# Altium schematic sheet 5.SchDoc(5)
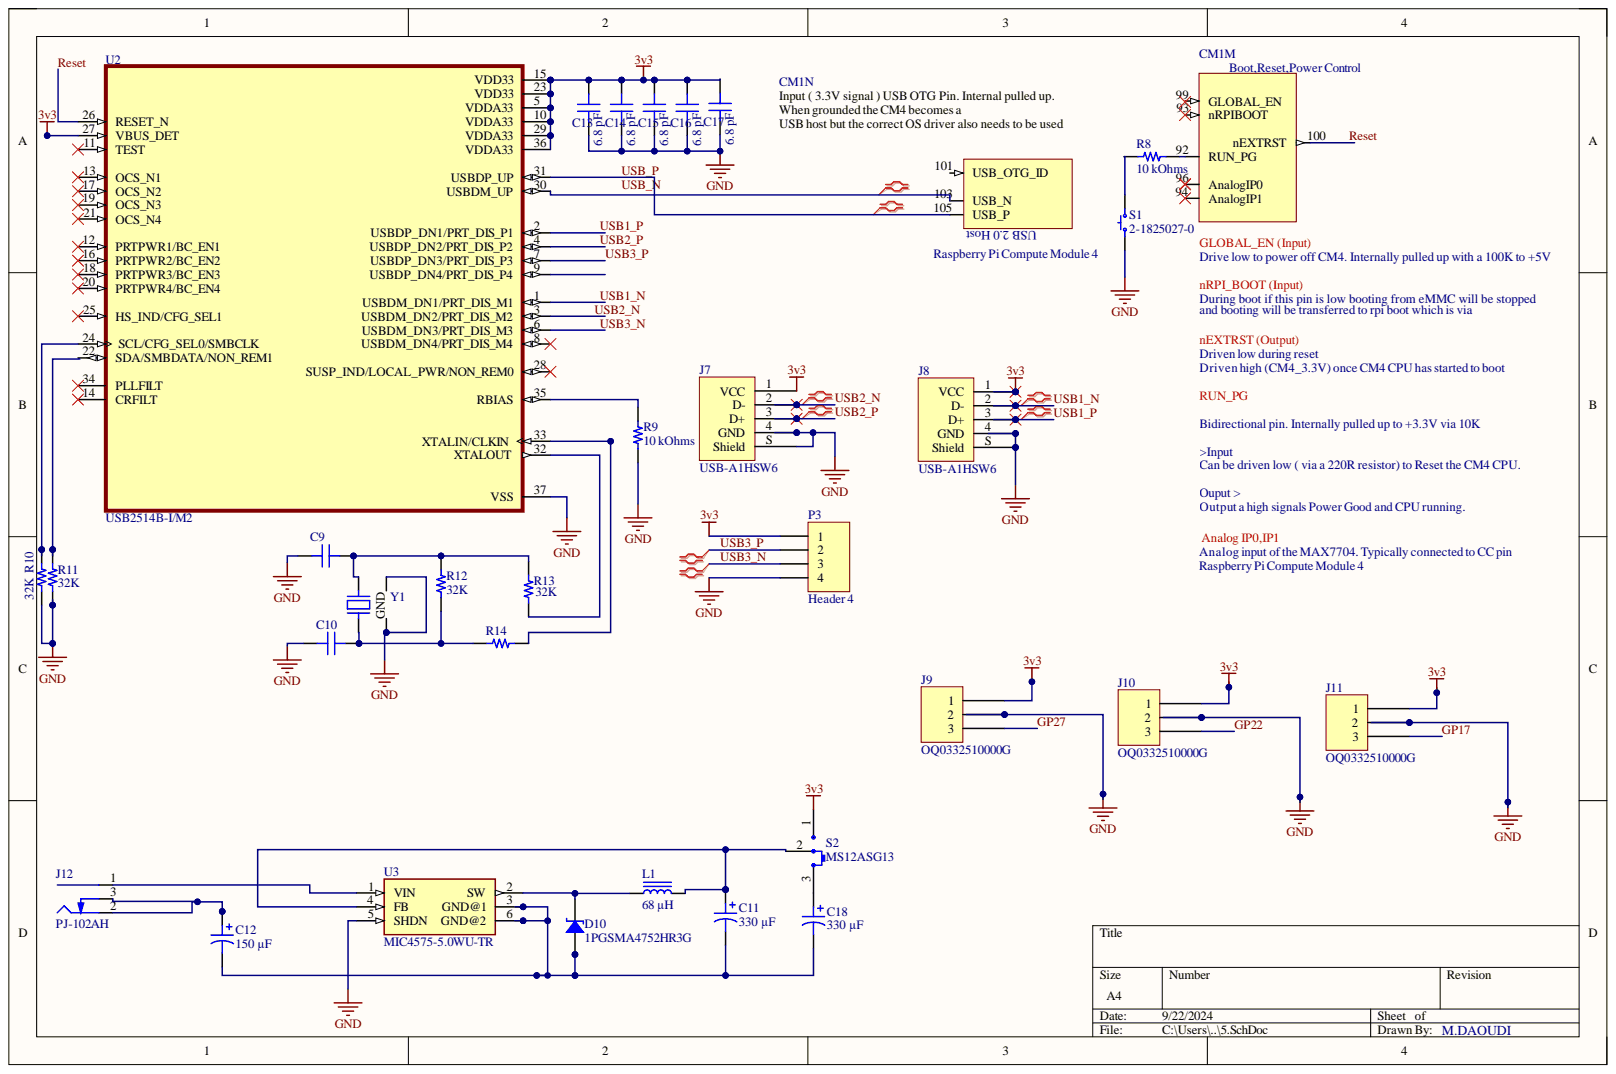
[diagram p0: 5.SchDoc]

## Parts
C9  =Value  [CAP 0201_0603 RF]
C10  =Value  [CAP 0201_0603 RF]
C11  330 µF  [CAP ALSMD 6.6MM H5.4MM]
C12  150 µF  [CAP ALSMD 8.3MM H10.5MM]
C13  6.8 pF  [CAP 0603_1608]
C14  6.8 pF  [CAP 0603_1608]
C15  6.8 pF  [CAP 0603_1608]
C16  6.8 pF  [CAP 0603_1608]
C17  6.8 pF  [CAP 0603_1608]
C18  330 µF  [CAP ALSMD 6.6MM H5.4MM]
CM1  Raspberry Pi Compute Module 4  [CM4-F40C-100DS-0.4v_1.5mm_0mm]  (no BOM)  (parts M,N)
D10  1PGSMA4752HR3G  [DO214AC SMA]
J7  USB-A1HSW6  [ON SHORE TECHNOLOGY USB-A1HSW6]
J8  USB-A1HSW6  [ON SHORE TECHNOLOGY USB-A1HSW6]
J9  OQ0332510000G  [FCI 06-381-3PIN]
J10  OQ0332510000G  [FCI 06-381-3PIN]
J11  OQ0332510000G  [FCI 06-381-3PIN]
J12  PJ-102AH  [CUI PJ-102AH]
L1  68 µH  [WURTH WE-PD 1280]
P3  Header 4  [HDR1X4]
R8  10 kOhms  [RES 0603_1608]
R9  10 kOhms  [RES 0603_1608]
R10  32K  [RES 0201_0603]
R11  32K  [RES 0201_0603]
R12  32K  [RES 0201_0603]
R13  32K  [RES 0201_0603]
R14  =VALUE  [RES 0201_0603]
S1  2-1825027-0  [TE 2-1825027-0]
S2  MS12ASG13  [NKK MS12ASG13]
U2  USB2514B-I/M2  [QFN50P600X600X100-37N]
U3  MIC4575-5.0WU-TR  [TO170P1524X460-6N]
Y1  =Value  [ABRACON ABM8G]

## Nets
3v3: C13.2 C14.2 C15.2 C16.2 C17.2 J7.1(VCC) J8.1(VCC) J9.1 J10.1 J11.1 P3.1 S2.1 U2.5(VDDA33) U2.10(VDDA33) U2.15(VDD33) U2.23(VDD33) U2.27(VBUS_DET) U2.29(VDDA33) U2.36(VDDA33)
GND: C9.1 C10.1 C13.1 C14.1 C15.1 C16.1 C17.1 J7.4(GND) J7.S(Shield) J8.4(GND) J8.S(Shield) J9.2 J10.2 J11.2 P3.4 R9.1 R10.1 R11.1 S1.1 U2.37(VSS) U3.5(SHDN) Y1.2(GND) Y1.4
GP17: J11.3
GP22: J10.3
GP27: J9.3
Reset: CM1.100 U2.26(RESET_N)
USB1_N: J8.2(D-) U2.1(USBDM_DN1/PRT_DIS_M1)
USB1_P: J8.3(D+) U2.2(USBDP_DN1/PRT_DIS_P1)
USB2_N: J7.2(D-) U2.3(USBDM_DN2/PRT_DIS_M2)
USB2_P: J7.3(D+) U2.4(USBDP_DN2/PRT_DIS_P2)
USB3_N: P3.3 U2.6(USBDM_DN3/PRT_DIS_M3)
USB3_P: P3.2 U2.7(USBDP_DN3/PRT_DIS_P3)
USB_P: CM1.105 U2.31(USBDP_UP)
NetC9_2: C9.2 R12.2 R13.2 Y1.3
NetC10_2: C10.2 R12.1 R14.1 Y1.1
NetC11_1: C11.1 L1.2 S2.2 U3.4(FB)
NetC11_2: C11.2 C12.2 C18.2 D10.1 U3.3(GND@1) U3.6(GND@2)
NetC12_1: C12.1 J12.2 J12.3
NetC18_1: C18.1 S2.3
NetCM1_92: CM1.92(RUN_PG) R8.1
NetCM1_103: CM1.103 U2.30(USBDM_UP)
NetD10_2: D10.2 L1.1 U3.2(SW)
NetJ12_1: J12.1 U3.1(VIN)
NetR8_2: R8.2 S1.2
NetR9_2: R9.2 U2.35(RBIAS)
NetR10_2: R10.2 U2.24(SCL/CFG_SEL0/SMBCLK)
NetR13_1: R13.1 U2.32(XTALOUT)
NetR14_2: R14.2 U2.33(XTALIN/CLKIN)
USB_N:

Not connected: CM1 93(nRPIBOOT) 94(AnalogIP1) 96(AnalogIP0) 99(GLOBAL_EN) 101; R11 2; U2 8(USBDM_DN4/PRT_DIS_M4) 9(USBDP_DN4/PRT_DIS_P4) 11(TEST) 12(PRTPWR1/BC_EN1) 13(OCS_N1) 14(CRFILT) 16(PRTPWR2/BC_EN2) 17(OCS_N2) 18(PRTPWR3/BC_EN3) 19(OCS_N3) 20(PRTPWR4/BC_EN4) 21(OCS_N4) 22(SDA/SMBDATA/NON_REM1) 25(HS_IND/CFG_SEL1) 28(SUSP_IND/LOCAL_PWR/NON_REM0) 34(PLLFILT)

## Library data of the parts
C9 C10 = CBR02C130J3GAC: CAP CER 13PF 25V C0G/NP0 0201; Database Table Name: Capacitors - Ceramic - RF; Component Kind: Standard; Library Name: Celestial Altium Library - altium_library.DbLib; Part Number: CBR02C130J3GAC
C11 C18 = UCM1C331MCL1GS: CAP ALUM 330UF 20% 16V SMD; manufacturer: Nichicon; Database Table Name: Capacitors - Aluminum - Electrolytic SMT; Impedance: 160 mOhms; Lifetime @ Temp.: 2000 Hrs @ 105°C; Polarization: Polar; Ripple Current @ High Frequency: 600 mA @ 100 kHz; Ripple Current @ Low Frequency: 300 mA @ 120 Hz; Surface Mount Land Size: 0.260" L x 0.260" W (6.60mm x 6.60mm); Applications: Automotive; Capacitance: 330 µF; Component Kind: Standard; componentlink1description: Datasheet; componentlink1url: http://nichicon-us.com/english/products/pdfs/e-ucm.pdf; componentlink2description: DigiKey Link; componentlink2url: https://www.digikey.com/en/products/detail/nichicon/UCM1C331MCL1GS/4991840; Device Package: Radial, Can - SMD; Height - Seated (Max): 0.311" (7.90mm); lastupdated: 6/3/2024 7:53:41 PM; Library Name: Celestial Altium Library - altium_library.DbLib; Lifecycle Status: Active; Minimum Order: 1; Mounting Type: Surface Mount; Operating Temperature: -55°C ~ 105°C; Package / Case: Radial, Can - SMD; packaging: TapeAndReel; Part Number: UCM1C331MCL1GS; Part Status: Active; partid: 9156; price: 0.16; Ratings: AEC-Q200; series: UCM; Size / Dimension: 0.248" Dia (6.30mm); Supplier 1: DigiKey; Supplier Part Number 1: 493-14528-1-ND; Tolerance: ±20%; value: 330 µF; Voltage - Rated: 16 V
C12 = EEE-FK1V151AP: CAP ALUM 150UF 20% 35V SMD; manufacturer: Panasonic Electronic Components; Database Table Name: Capacitors - Aluminum - Electrolytic SMT; Impedance: 160 mOhms; Lifetime @ Temp.: 2000 Hrs @ 105°C; Polarization: Polar; Ripple Current @ High Frequency: 600 mA @ 100 kHz; Ripple Current @ Low Frequency: 390 mA @ 120 Hz; Surface Mount Land Size: 0.327" L x 0.327" W (8.30mm x 8.30mm); Applications: Automotive, High Temperature Reflow; Capacitance: 150 µF; Component Kind: Standard; componentlink1description: Datasheet; componentlink1url: http://industrial.panasonic.com/www-cgi/jvcr13pz.cgi?E+PZ+3+ABA0088+EEEFK1V151AP+7+WW; componentlink2description: DigiKey Link; componentlink2url: https://www.digikey.com/en/products/detail/panasonic-electronic-components/EEE-FK1V151AP/1141986; Device Package: Radial, Can - SMD; Height - Seated (Max): 0.413" (10.50mm); lastupdated: 6/3/2024 8:15:44 PM; Library Name: Celestial Altium Library - altium_library.DbLib; Lifecycle Status: Active; Minimum Order: 1; Mounting Type: Surface Mount; Operating Temperature: -55°C ~ 105°C; Package / Case: Radial, Can - SMD; packaging: TapeAndReel; Part Number: EEE-FK1V151AP; Part Status: Active; partid: 7774; price: 0.25; Ratings: AEC-Q200; series: FK; Size / Dimension: 0.315" Dia (8.00mm); Supplier 1: DigiKey; Supplier Part Number 1: PCE4427CT-ND; Tolerance: ±20%; value: 150 µF; Voltage - Rated: 35 V
C13-C17 = 0603N6R8BW251: .060 X.030 6.8 PF .1PF 250 VOLTS; manufacturer: Passive Plus, Inc.; Database Table Name: Capacitors - Ceramic - 0603; Temperature Coefficient: C0G, NP0; Tolerance: ±0.1pF; Voltage - Rated: 250V; Applications: RF, Microwave, High Frequency; Capacitance: 6.8 pF; Component Kind: Standard; componentlink2description: DigiKey Link; componentlink2url: https://www.digikey.com/en/products/detail/passive-plus-inc/0603N6R8BW251/18675199; Device Package: 0603 (1608 Metric); Features: High Q, Low Loss, Low ESL; lastupdated: 2/9/2024 8:09:38 PM; Library Name: Celestial Altium Library - altium_library.DbLib; Lifecycle Status: Active; Minimum Order: 1; Mounting Type: Surface Mount, MLCC; Operating Temperature: -55°C ~ 175°C; Package / Case: 0603 (1608 Metric); packaging: TapeAndReel; Part Number: 0603N6R8BW251; Part Status: Active; partid: 50011; price: 0.33; series: 0603N; Size / Dimension: 0.062" L x 0.032" W (1.57mm x 0.81mm); Supplier 1: DigiKey; Supplier Part Number 1: 4363-0603N6R8BW251CT-ND; Thickness (Max): 0.038" (0.96mm); Value: 6.8 pF
CM1 = CM4: Raspberry Pi Compute Module 4 by Amornthep Phunsin; Library Name: CM4.SchLib
D10 = 1PGSMA4752HR3G: DIODE ZENER; Manufacturer: Taiwan Semiconductor Corporation; Current - Reverse Leakage @ Vr: 1µA @ 25.1V; Database Table Name: Diodes - Zener - Single; Impedance (Max) (Zzt): 45 Ohms; Power - Max: 1.25W; Tolerance: ±5%; Voltage - Forward (Vf) (Max) @ If: 1.2V @ 200mA; Voltage - Zener (Nom) (Vz): 33V; Component Kind: Standard; ComponentLink1Description: Datasheet; ComponentLink1URL: https://www.taiwansemi.com/products/datasheet/1PGSMA%20SERIES_A1707.pdf; ComponentLink2Description: DigiKey Link; ComponentLink2URL: http://digikey.com/product-detail/en/taiwan-semiconductor-corporation/1PGSMA4752HR3G/1PGSMA4752HR3GCT-ND/8600492; LastUpdated: 03/06/2024 19:55:30; Library Name: Celestial Altium Library - altium_library.DbLib; Lifecycle Status: Active; Minimum Order: 1; Mounting Type: Surface Mount; Operating Temperature: -55°C ~ 175°C (TJ); Package / Case: DO-214AC, SMA; Packaging: TapeAndReel; Part Number: 1PGSMA4752HR3G; Part Status: Active; PartId: 1152; Price: 0.11; Series: Automotive, AEC-Q101; Supplier 1: DigiKey; Supplier Device Package: DO-214AC (SMA); Supplier Part Number 1: 1PGSMA4752HR3GCT-ND
J7 J8 = USB-A1HSW6: CONN RCPT TYPEA 4POS R/A; Manufacturer: On Shore Technology Inc.; Connector Type: USB - A; Current Rating (Amps): 1A; Database Table Name: Connectors - USB DVI HDMI; Gender: Receptacle; Number of Contacts: 4; Number of Ports: 1; Shielding: Unshielded; Voltage - Rated: 30VAC; Component Kind: Standard; ComponentLink1Description: Datasheet; ComponentLink1URL: http://www.on-shore.com/wp-content/uploads/USB-A1HSXX.pdf; ComponentLink2Description: DigiKey Link; ComponentLink2URL: http://digikey.com/product-detail/en/on-shore-technology-inc/USB-A1HSW6/ED2989-ND/2677750; Features: Board Lock; LastUpdated: 30/04/2020 11:56:44; Library Name: Celestial Altium Library - altium_library.DbLib; Lifecycle Status: Active; Minimum Order: 1; Mounting Feature: Horizontal; Mounting Type: Through Hole, Right Angle; Operating Temperature: 0°C ~ 85°C; Packaging: Tray; Part Number: USB-A1HSW6; Part Status: Active; Price: 0.48; Series: -; Supplier 1: DigiKey; Supplier Part Number 1: ED2989-ND; Termination: Solder
J9-J11 = OQ0332510000G: TERM BLOCK HDR 3POS 90DEG 3.81MM; Manufacturer: Amphenol Anytek; Current - UL: 10A; Database Table Name: Connectors - Terminal Blocks - Headers Plugs and Sockets; Header Orientation: 90°, Right Angle; Number of Levels: 1; Number of Positions: 3; Pitch: 0.150" (3.81mm); Voltage - UL: 300V; Color: Green; Component Kind: Standard; ComponentLink1Description: Datasheet; ComponentLink1URL: http://portal.fciconnect.com/Comergent//fci/drawing/oqxx32x100j0g.pdf; ComponentLink2Description: DigiKey Link; ComponentLink2URL: //digikey.com/product-detail/en/amphenol-anytek/OQ0332510000G/609-3820-ND/2261261; Contact Mating Finish: Tin; LastUpdated: 12/16/2019 7:14:46 PM; Library Name: Celestial Altium Library - altium_library.DbLib; Lifecycle Status: 0; Minimum Order: 1; Mounting Type: Through Hole; Operating Temperature: -40°C ~ 115°C; Packaging: Bulk; Part Number: OQ0332510000G; Part Status: Active; Positions Per Level: 3; Price: 0.53; Series: 06-381; Supplier 1: DigiKey; Supplier Part Number 1: 609-3820-ND; Termination Style: Solder; Type: Header, Male Pins, Shrouded (4 Side)
J12 = PJ-102AH: CONN PWR JACK 2X5.5MM SOLDER; Manufacturer: CUI Devices; Actual Diameter: 0.079" (2.00mm ID), 0.256" (6.50mm OD); Current Rating (Amps): 5A; Database Table Name: Connectors - Barrel - Power; Gender: Male; Industry Recognized Mating Diameter: 2.00mm ID (0.079"), 5.50mm OD (0.217"); Internal Switch(s): Single Switch, Normally Closed; Material Flammability Rating: UL94 V-0; Mating Length/Depth: 0.354" (9.00mm); Number of Positions/Contacts: 2 Conductors, 3 Contacts; Shielding: Unshielded; Voltage - Rated: 24VDC; Component Kind: Standard; ComponentLink1Description: Datasheet; ComponentLink1URL: https://www.cuidevices.com/product/resource/digikeypdf/pj-102ah.pdf; ComponentLink2Description: DigiKey Link; ComponentLink2URL: //digikey.com/product-detail/en/cui-devices/PJ-102AH/CP-102AH-ND/408448; Connector Type: Jack; LastUpdated: 16/12/2019 19:01:27; Library Name: Celestial Altium Library - altium_library.DbLib; Lifecycle Status: 0; Minimum Order: 1; Mounting Type: Through Hole, Right Angle; Packaging: Tray; Part Number: PJ-102AH; Part Status: Active; Price: 0.76; Series: -; Supplier 1: DigiKey; Supplier Part Number 1: CP-102AH-ND; Termination: Solder
L1 = 744770168: FIXED IND 68UH 2.3A 140 MOHM SMD; Manufacturer: Würth Elektronik; Current - Saturation: 2.5A; Database Table Name: Inductors - Power; DC Resistance (DCR): 140mOhm Max; Frequency - Self Resonant: 6.4MHz; Inductance Frequency - Test: 1 kHz; Material - Core: Ferrite; Shielding: Shielded; Tolerance: ±20%; Type: Drum Core, Wirewound; Component Kind: Standard; ComponentLink1Description: Datasheet; ComponentLink1URL: https://katalog.we-online.de/pbs/datasheet/744770168.pdf; ComponentLink2Description: DigiKey Link; ComponentLink2URL: https://www.digikey.com/en/products/detail/würth-elektronik/744770168/1638638; Current Rating (Amps): 2.3 A; Device Package: Nonstandard; Height - Seated (Max): 0.315" (8.00mm); Inductance: 68 µH; LastUpdated: 03/06/2024 20:26:21; Library Name: Celestial Altium Library - altium_library.DbLib; Lifecycle Status: Active; Minimum Order: 1; Mounting Type: Surface Mount; Operating Temperature: -40°C ~ 125°C; Package / Case: Nonstandard; Packaging: TapeAndReel; Part Number: 744770168; Part Status: Active; PartId: 5759; Price: 1.33; Ratings: AEC-Q200; Series: WE-PD; Size / Dimension: 0.472" L x 0.472" W (12.00mm x 12.00mm); Supplier 1: DigiKey; Supplier Part Number 1: 732-1233-1-ND; Value: 68 µH
P3 = Header 4: Header, 4-Pin; Component Kind: Standard; LatestRevisionDate: 17-Jul-2002; LatestRevisionNote: Re-released for DXP Platform.; Library Name: Miscellaneous Connectors.IntLib; Signal Integrity: Connector; Publisher: Altium Limited
R8 R9 = CRCW060310K0FKEE: RES SMD 10K OHM 1% 1/10W 0603; Manufacturer: Vishay Dale; Database Table Name: Resistors - Surface Mount - 0603; Power (Watts): 0.1W, 1/10W; Temperature Coefficient: ±100ppm/°C; Component Kind: Standard; ComponentLink1Description: Datasheet; ComponentLink1URL: https://www.vishay.com/docs/20035/dcrcwe3.pdf; ComponentLink2Description: DigiKey Link; ComponentLink2URL: https://www.digikey.com/en/products/detail/vishay-dale/CRCW060310K0FKEE/5070674; Composition: Thick Film; Device Package: 0603 (1608 Metric); Features: Automotive AEC-Q200; Height - Seated (Max): 0.020" (0.50mm); LastUpdated: 2/9/2024 8:40:40 PM; Library Name: Celestial Altium Library - altium_library.DbLib; Lifecycle Status: Active; Minimum Order: 1; Number of Terminations: 2; Operating Temperature: -55°C ~ 155°C; Package / Case: 0603 (1608 Metric); Packaging: CutTape; Part Number: CRCW060310K0FKEE; Part Status: Active; PartId: 78260; Price: 0.01; Ratings: AEC-Q200; Resistance: 10 kOhms; Series: CRCW; Size / Dimension: 0.063" L x 0.033" W (1.60mm x 0.85mm); Supplier 1: DigiKey; Supplier Device Package: 0603; Supplier Part Number 1: 541-CRCW060310K0FKEECT-ND; Tolerance: ±1%; Value: 10 kOhms
R10-R14 = 7-2176074-4: RES SMD 33.2KOHM 0.5% 1/32W 0201; Database Table Name: Resistors - Surface Mount - 0201; Component Kind: Standard; Library Name: Celestial Altium Library - altium_library.DbLib; Part Number: 7-2176074-4
S1 = 2-1825027-0: SWITCH TACTILE SPST-NO 0.05A 24V; Manufacturer: TE Connectivity ALCOSWITCH Switches; Actuator Length, Right Angle: 11.85mm; Actuator Orientation: Side Actuated; Actuator Type: Standard; Contact Rating @ Voltage: 0.05A @ 24VDC; Database Table Name: Switches - Tactile; Illumination: Non-Illuminated; Operating Force: 160gf; Outline: 7.11mm x 6.60mm; Switch Function: Off-Mom; Circuit: SPST-NO; Component Kind: Standard; ComponentLink1Description: Datasheet; ComponentLink1URL: https://www.te.com/commerce/DocumentDelivery/DDEController?Action=srchrtrv&DocNm=1308111-1_SWITCHES_CORE_PROGRAM_CATALOG&DocType=CS&DocLang=English; ComponentLink2Description: DigiKey Link; ComponentLink2URL: http://digikey.com/product-detail/en/te-connectivity-alcoswitch-switches/2-1825027-0/450-1661-ND/1632547; LastUpdated: 9/6/2020 11:08:27 AM; Library Name: Celestial Altium Library - altium_library.DbLib; Lifecycle Status: Active; Minimum Order: 1; Mounting Type: Through Hole, Right Angle; Operating Temperature: -35°C ~ 85°C; Packaging: Bulk; Part Number: 2-1825027-0; Part Status: Active; Price: 0.22; Series: FSMRAJ; Supplier 1: DigiKey; Supplier Part Number 1: 450-1661-ND; Termination Style: PC Pin
S2 = MS12ASG13: SWITCH SLIDE SPDT 0.4VA 28V; Manufacturer: NKK Switches; Actuator Length: 5.10mm; Actuator Type: Standard; Contact Timing: Non-Shorting (BBM); Current Rating (Amps): 0.4VA (AC/DC); Database Table Name: Switches - Slide; Switch Function: On-On; Circuit: SPDT; Component Kind: Standard; ComponentLink1Description: Datasheet; ComponentLink1URL: https://www.nkkswitches.com/pdf/MSnonilluminated.pdf; ComponentLink2Description: DigiKey Link; ComponentLink2URL: https://www.digikey.com/en/products/detail/nkk-switches/MS12ASG13/1052535; Contact Finish: Gold; Contact Material: Copper; Features: Epoxy Sealed Terminals; LastUpdated: 9/26/2022 3:18:31 AM; Library Name: Celestial Altium Library - altium_library.DbLib; Lifecycle Status: Active; Minimum Order: 1; Mounting Type: Through Hole; Operating Temperature: -30°C ~ 85°C; Packaging: Bulk; Part Number: MS12ASG13; Part Status: Active; PartId: 6; Price: 2.95; Series: MS; Supplier 1: DigiKey; Supplier Part Number 1: 360-2388-ND; Termination Style: PC Pin; Voltage Rating - AC: 28 V; Voltage Rating - DC: 28 V
U2 = USB2514B-I/M2: USB Hub Controller - USB Interface 36-SQFN (6x6); MF: Microchip; Check_prices: https://www.snapeda.com/parts/USB2514B-I/M2/Microchip/view-part/?ref=eda; Component Kind: Standard; Library Name: USB2514B-I_M2.IntLib; MP: USB2514B-I/M2; Package: VQFN-36 Microchip; SnapEDA_Link: https://www.snapeda.com/parts/USB2514B-I/M2/Microchip/view-part/?ref=snap
U3 = MIC4575-5.0WU-TR: MIC4575 Series 24 V 200 kHz 1 A Surface Mount Simple Buck Regulator - TO-263-5; Availability: Unavailable; Component Kind: Standard; Library Name: MIC4575-5.0WU-TR.IntLib; MP: MIC4575-5.0WU-TR; Package: None; Price: None
Y1 = ABM8G-32.000MHZ-B4Y-T: CRYSTAL 32.0000MHZ 10PF SMD; Database Table Name: Oscillators - Crystals; Component Kind: Standard; Library Name: Celestial Altium Library - altium_library.DbLib; Part Number: ABM8G-32.000MHZ-B4Y-T
